# Altium schematic sheet Stage3D_neg_voltage.SchDoc
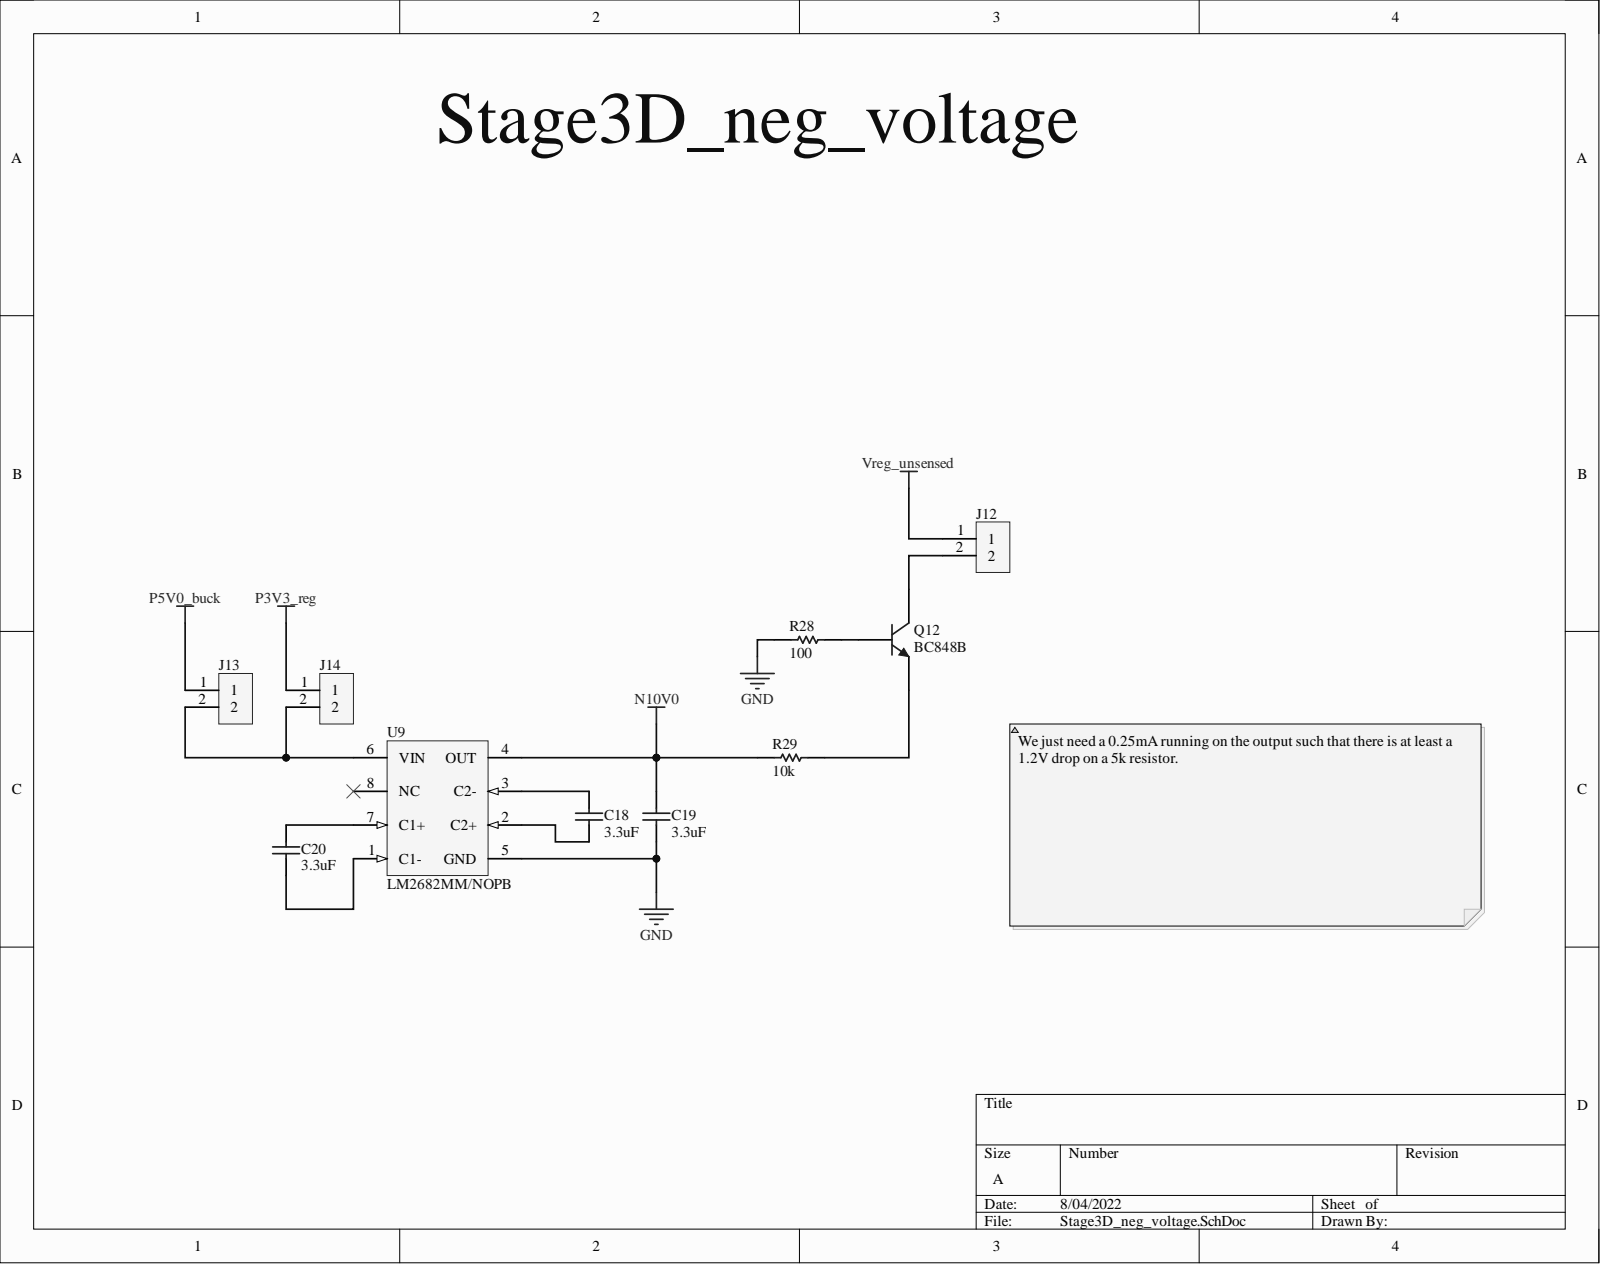
[diagram p0: Stage3D_neg_voltage.SchDoc]

## Parts
C18  3.3uF  [CAPC2013X140X50NL20T25]
C19  3.3uF  [CAPC2013X140X50NL20T25]
C20  3.3uF  [CAPC2013X140X50NL20T25]
J12  (no value)  [PCB-omgsbkhp90n7lpri88og-1]
J13  (no value)  [PCB-omgsbkhp90n7lpri88og-1]
J14  (no value)  [PCB-omgsbkhp90n7lpri88og-1]
Q12  BC848B  [PCB-hbuntr9r1m1073lqpw4t-1]
R28  100  [PCB-0002-00380-8]
R29  10k  [PCB-7wrvqjq7seaxrusqyahf-1]
U9  LM2682MM/NOPB  [MUA08A_L]

## Nets
GND: C19.1 R28.1 U9.5(GND)
N10V0: C19.2 R29.1 U9.4(OUT)
P3V3_reg: J14.1
P5V0_buck: J13.1
Vreg_unsensed: J12.1
NetC18_1: C18.1 U9.2(C2+)
NetC18_2: C18.2 U9.3(C2-)
NetC20_1: C20.1 U9.1(C1-)
NetC20_2: C20.2 U9.7(C1+)
NetJ12_2: J12.2 Q12.1
NetJ13_2: J13.2 J14.2 U9.6(VIN)
NetQ12_2: Q12.2 R28.2
NetQ12_3: Q12.3 R29.2

Not connected: U9 8(NC)

## Library data of the parts
C18-C20 = CMP-126874-2: CAP CER 3.3UF 10V 10% X5R 0805; Manufacturer Part Number: 0805ZD335KAT2A; Capacitance: 3.3e-06 F; Case/Package (SI): 2012; Dielectric Material: Ceramic Multilayer; Lead-Free Status: Lead Free; Voltage Rating (DC): 10.0 V; Capacitance Tolerance: ±10%; Case/Package: 0805; Component Kind: Standard; Dielectric Characteristic: X5R; Library Name: My Personal Space; Mounting Style: Surface Mount; Number of Pins: 2; Octopart Url: https://octopart.com/0805zd335kat2a-avx+interconnect+%2F+elco-194965; OctopartID: c4580d5018ab1b9f; REACH SVHC Compliance: No SVHC; RoHS: Compliant; Size-Height: 0.0014 m; Size-Length: 0.00201 m; Size-Width: 0.00125 m
J12-J14 = CMP-748fbcb73d6bab48-30: Pitch 2.54 mm, Single Row, 2pins; Component Kind: Standard; Library Name: My Personal Space
Q12 = CMP-64f7a94c3727bb30-1: BC848; Manufacturer Part Number: BC848B,215; Lead-Free Status: Lead Free; Polarity: NPN, N-Channel; Case/Package: SOT-23; Component Kind: Standard; Library Name: My Personal Space; Lifecycle Status: Active; Mounting Style: Surface Mount; Number of Pins: 3; Octopart URL: https://octopart.com/bc848b%2C215-nexperia-78739126; OctopartID: 64f7a94c3727bb30; Operating Temperature: -65.0 °C to 150 °C; Packaging: Cut Tape (CT), Tape & Reel (TR); REACH SVHC Compliance: No SVHC; RoHS: Compliant
R28 = CMP-1854308-9: RES SMD 100 OHM 1% 1/8W 0805; Component Kind: Standard; Library Name: My Personal Space
R29 = CMP-ce7e062e016b11b9-5: Res Thin Film 0805 10K Ohm 0.1% 1/10W ±25ppm/°C Molded SMD SMD Paper T/R; Manufacturer Part Number: CPF0805B10KE; Case/Package (SI): 2012; ELV Compliant: Compliant; Power Rating: 100 mW; Resistance: 10.0 kΩ; Case/Package: 0805; Component Kind: Standard; Dimensions: 2 x 1.25 x 0.55mm; Library Name: My Personal Space; Lifecycle Status: Active; Mounting Style: Surface Mount; Octopart URL: https://octopart.com/cpf0805b10ke-te+connectivity-22735475; OctopartID: ce7e062e016b11b9; Packaging: Tape & Reel (TR); RoHS: Compliant
U9 = CMP-0065-00105-3: Switched Capacitor Voltage Doubling Inverter, 8-pin Mini SOIC, Pb-Free; Lead-Free Status: Lead Free; Number of Outputs: 1; Output Current: 0.01 A; Switching Frequency: 6000.0 Hz; Case/Package: SOIC; Component Kind: Standard; Library Name: My Personal Space; Lifecycle Status: Active; Manufacturer Part Number: LM2682MM/NOPB; Mounting Style: Surface Mount; Number of Pins: 8; Octopart Url: https://octopart.com/lm2682mm%2Fnopb-texas+instruments-24813185; OctopartID: b09378e30b746f54; Packaging: Cut Tape (CT); RoHS: Compliant
